# Altium schematic sheet ICWSHLP2_Adaptor_2x2_Connector_FMC.SchDoc(ICWSHLP2_Adaptor_2x2_Connector_FMC)
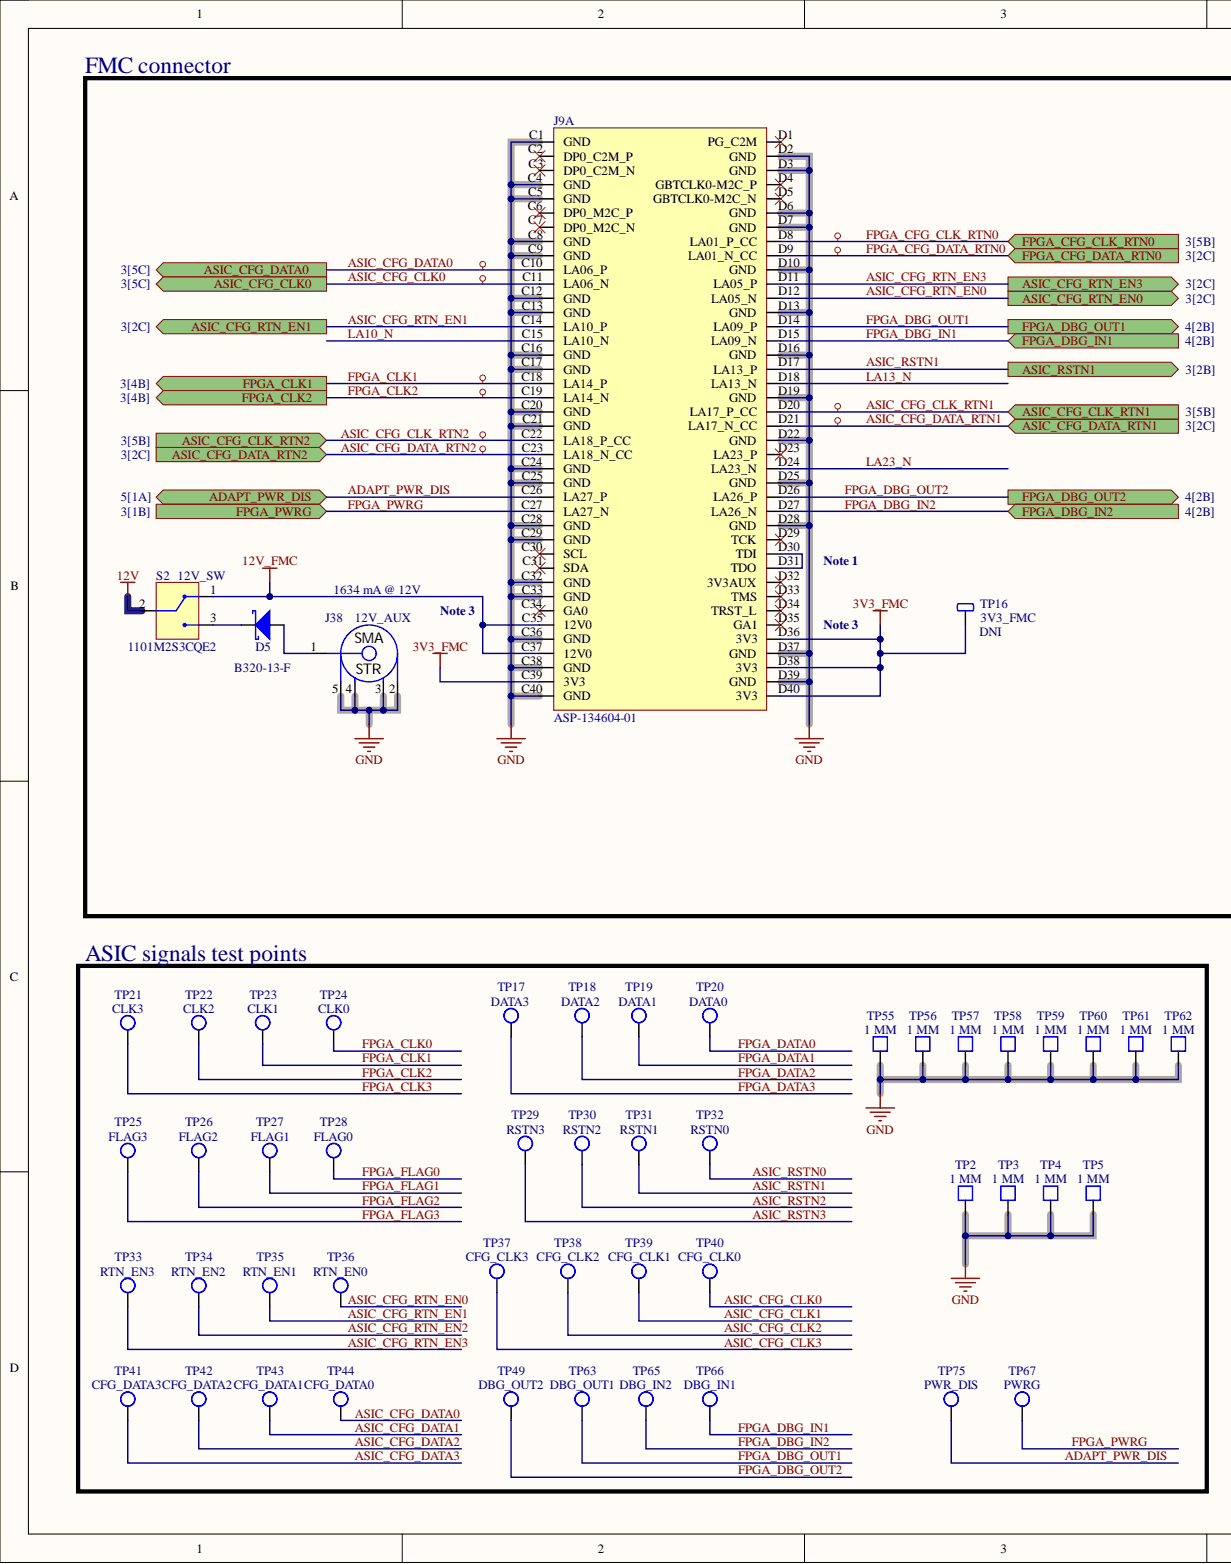
[diagram p0: ICWSHLP2_Adaptor_2x2_Connector_FMC.SchDoc, left]
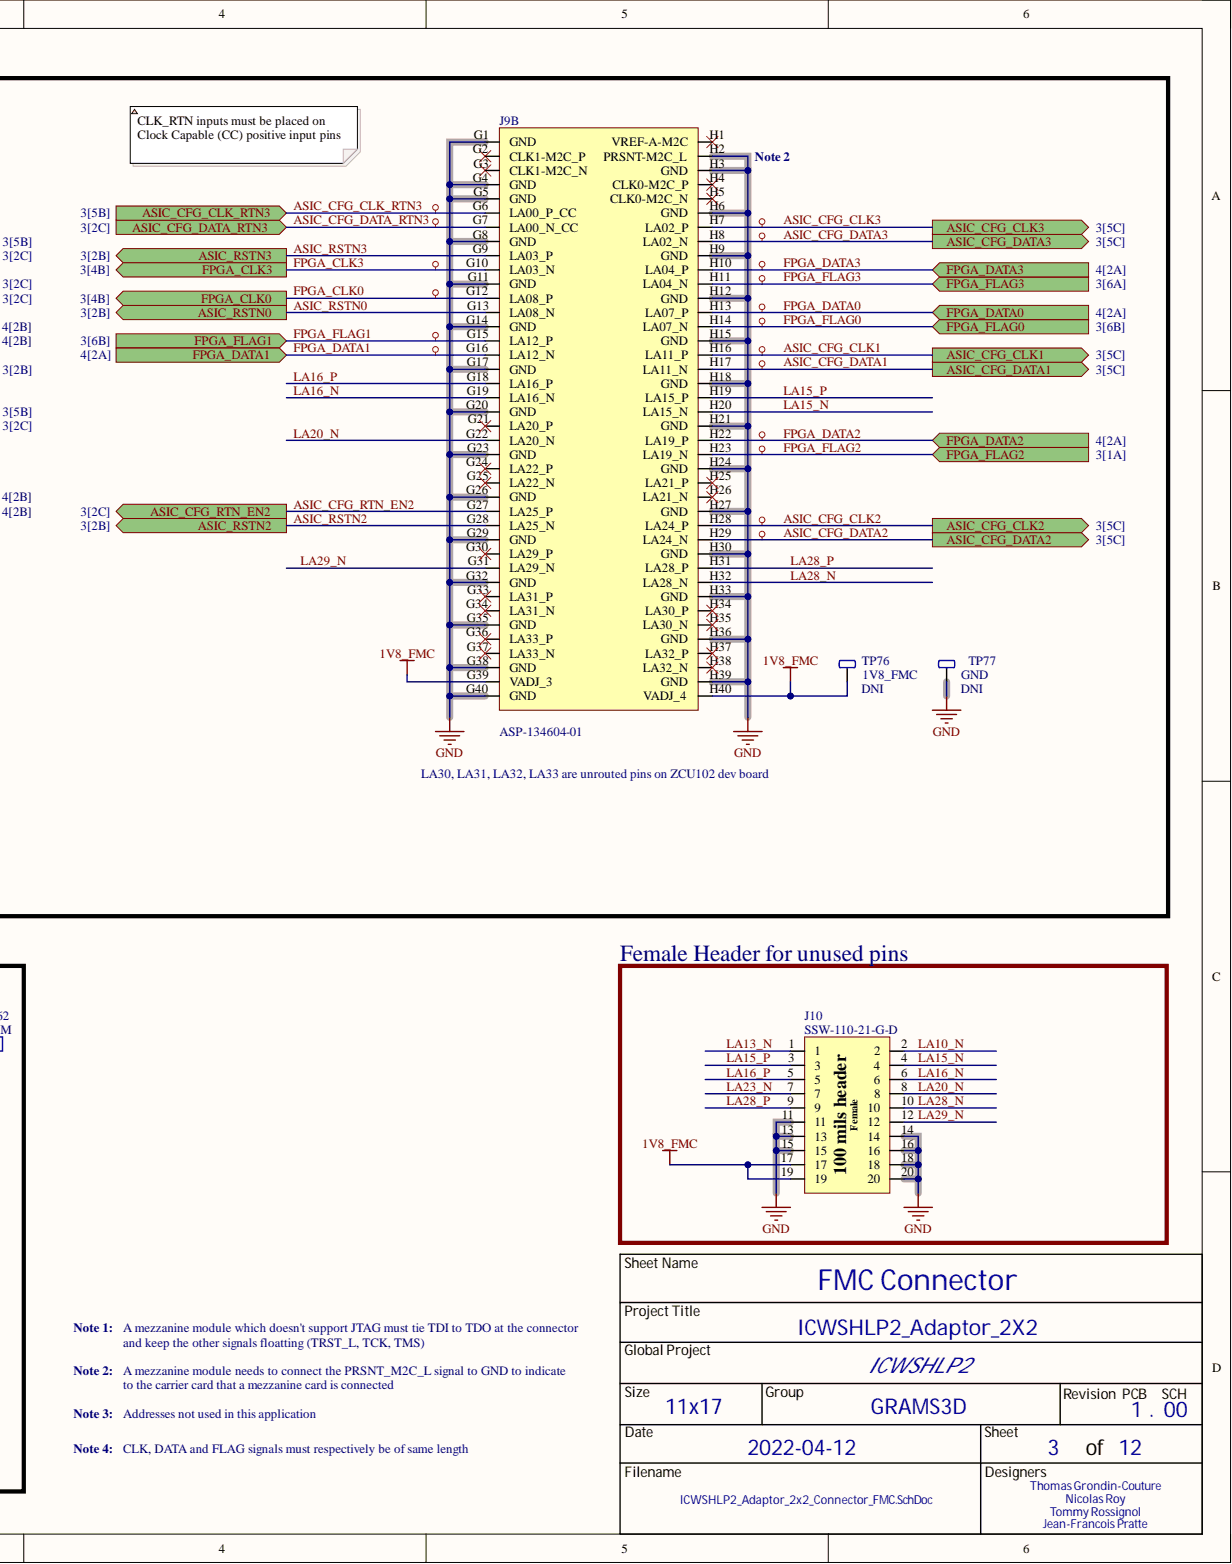
[diagram p1: ICWSHLP2_Adaptor_2x2_Connector_FMC.SchDoc, right]

## Parts
D5  B320-13-F  [DIO_SCHOTTKY_B320-13-F]
J9  ASP-134604-01  Note: MOD_ZedBoard_FMC  [CON_HDR_FMC_LPC_ASP-134604-01]  (parts A,B)
J10  SSW-110-21-G-D  [CON_HDR_SSW-110-21-G-D]
J38  12V_AUX  [CON_SMA_5-1814832-1]
S2  1101M2S3CQE2  [SWI_1101M2S3CQE2]
TP2  1 MM  [TPO_SMD_SQUARE_1MM]  (no BOM)
TP3  1 MM  [TPO_SMD_SQUARE_1MM]  (no BOM)
TP4  1 MM  [TPO_SMD_SQUARE_1MM]  (no BOM)
TP5  1 MM  [TPO_SMD_SQUARE_1MM]  (no BOM)
TP16  3V3_FMC  Assembly Note: DNI  [TPO_SMD_RCU-0C]
TP17  DATA3  [TPO_SMD_ROUND_1MM]  (no BOM)
TP18  DATA2  [TPO_SMD_ROUND_1MM]  (no BOM)
TP19  DATA1  [TPO_SMD_ROUND_1MM]  (no BOM)
TP20  DATA0  [TPO_SMD_ROUND_1MM]  (no BOM)
TP21  CLK3  [TPO_SMD_ROUND_1MM]  (no BOM)
TP22  CLK2  [TPO_SMD_ROUND_1MM]  (no BOM)
TP23  CLK1  [TPO_SMD_ROUND_1MM]  (no BOM)
TP24  CLK0  [TPO_SMD_ROUND_1MM]  (no BOM)
TP25  FLAG3  [TPO_SMD_ROUND_1MM]  (no BOM)
TP26  FLAG2  [TPO_SMD_ROUND_1MM]  (no BOM)
TP27  FLAG1  [TPO_SMD_ROUND_1MM]  (no BOM)
TP28  FLAG0  [TPO_SMD_ROUND_1MM]  (no BOM)
TP29  RSTN3  [TPO_SMD_ROUND_1MM]  (no BOM)
TP30  RSTN2  [TPO_SMD_ROUND_1MM]  (no BOM)
TP31  RSTN1  [TPO_SMD_ROUND_1MM]  (no BOM)
TP32  RSTN0  [TPO_SMD_ROUND_1MM]  (no BOM)
TP33  RTN_EN3  [TPO_SMD_ROUND_1MM]  (no BOM)
TP34  RTN_EN2  [TPO_SMD_ROUND_1MM]  (no BOM)
TP35  RTN_EN1  [TPO_SMD_ROUND_1MM]  (no BOM)
TP36  RTN_EN0  [TPO_SMD_ROUND_1MM]  (no BOM)
TP37  CFG_CLK3  [TPO_SMD_ROUND_1MM]  (no BOM)
TP38  CFG_CLK2  [TPO_SMD_ROUND_1MM]  (no BOM)
TP39  CFG_CLK1  [TPO_SMD_ROUND_1MM]  (no BOM)
TP40  CFG_CLK0  [TPO_SMD_ROUND_1MM]  (no BOM)
TP41  CFG_DATA3  [TPO_SMD_ROUND_1MM]  (no BOM)
TP42  CFG_DATA2  [TPO_SMD_ROUND_1MM]  (no BOM)
TP43  CFG_DATA1  [TPO_SMD_ROUND_1MM]  (no BOM)
TP44  CFG_DATA0  [TPO_SMD_ROUND_1MM]  (no BOM)
TP49  DBG_OUT2  [TPO_SMD_ROUND_1MM]  (no BOM)
TP55  1 MM  [TPO_SMD_SQUARE_1MM]  (no BOM)
TP56  1 MM  [TPO_SMD_SQUARE_1MM]  (no BOM)
TP57  1 MM  [TPO_SMD_SQUARE_1MM]  (no BOM)
TP58  1 MM  [TPO_SMD_SQUARE_1MM]  (no BOM)
TP59  1 MM  [TPO_SMD_SQUARE_1MM]  (no BOM)
TP60  1 MM  [TPO_SMD_SQUARE_1MM]  (no BOM)
TP61  1 MM  [TPO_SMD_SQUARE_1MM]  (no BOM)
TP62  1 MM  [TPO_SMD_SQUARE_1MM]  (no BOM)
TP63  DBG_OUT1  [TPO_SMD_ROUND_1MM]  (no BOM)
TP65  DBG_IN2  [TPO_SMD_ROUND_1MM]  (no BOM)
TP66  DBG_IN1  [TPO_SMD_ROUND_1MM]  (no BOM)
TP67  PWRG  [TPO_SMD_ROUND_1MM]  (no BOM)
TP75  PWR_DIS  [TPO_SMD_ROUND_1MM]  (no BOM)
TP76  1V8_FMC  Assembly Note: DNI  [TPO_SMD_RCU-0C]
TP77  GND  Assembly Note: DNI  [TPO_SMD_RCU-0C]

## Ports
ADAPT_PWR_DIS, ASIC_CFG_CLK0, ASIC_CFG_CLK1, ASIC_CFG_CLK2, ASIC_CFG_CLK3, ASIC_CFG_CLK_RTN1, ASIC_CFG_CLK_RTN2, ASIC_CFG_CLK_RTN3, ASIC_CFG_DATA0, ASIC_CFG_DATA1, ASIC_CFG_DATA2, ASIC_CFG_DATA3, ASIC_CFG_DATA_RTN1, ASIC_CFG_DATA_RTN2, ASIC_CFG_DATA_RTN3, ASIC_CFG_RTN_EN0, ASIC_CFG_RTN_EN1, ASIC_CFG_RTN_EN2, ASIC_CFG_RTN_EN3, ASIC_RSTN0, ASIC_RSTN1, ASIC_RSTN2, ASIC_RSTN3, FPGA_CFG_CLK_RTN0, FPGA_CFG_DATA_RTN0, FPGA_CLK0, FPGA_CLK1, FPGA_CLK2, FPGA_CLK3, FPGA_DATA0, FPGA_DATA1, FPGA_DATA2, FPGA_DATA3, FPGA_DBG_IN1, FPGA_DBG_IN2, FPGA_DBG_OUT1, FPGA_DBG_OUT2, FPGA_FLAG0, FPGA_FLAG1, FPGA_FLAG2, FPGA_FLAG3, FPGA_PWRG

## Nets
1V8_FMC: J9.G39(VADJ_3) J9.H40(VADJ_4) J10.17 J10.19 TP76.1
3V3_FMC: J9.C39(3V3) J9.D36(3V3) J9.D38(3V3) J9.D40(3V3) TP16.1
12V: S2.2
12V_FMC: J9.C35(12V0) J9.C37(12V0) S2.1
GND: J9.C1(GND) J9.C4(GND) J9.C5(GND) J9.C8(GND) J9.C9(GND) J9.C12(GND) J9.C13(GND) J9.C16(GND) J9.C17(GND) J9.C20(GND) J9.C21(GND) J9.C24(GND) J9.C25(GND) J9.C28(GND) J9.C29(GND) J9.C32(GND) J9.C33(GND) J9.C36(GND) J9.C38(GND) J9.C40(GND) J9.D2(GND) J9.D3(GND) J9.D6(GND) J9.D7(GND) J9.D10(GND) J9.D13(GND) J9.D16(GND) J9.D19(GND) J9.D22(GND) J9.D25(GND) J9.D28(GND) J9.D37(GND) J9.D39(GND) J9.G1(GND) J9.G4(GND) J9.G5(GND) J9.G8(GND) J9.G11(GND) J9.G14(GND) J9.G17(GND) J9.G20(GND) J9.G23(GND) J9.G26(GND) J9.G29(GND) J9.G32(GND) J9.G35(GND) J9.G38(GND) J9.G40(GND) J9.H2(PRSNT-M2C_L) J9.H3(GND) J9.H6(GND) J9.H9(GND) J9.H12(GND) J9.H15(GND) J9.H18(GND) J9.H21(GND) J9.H24(GND) J9.H27(GND) J9.H30(GND) J9.H33(GND) J9.H36(GND) J9.H39(GND) J10.11 J10.13 J10.14 J10.15 J10.16 J10.18 J10.20 J38.2 J38.3 J38.4 J38.5 TP2.1 TP3.1 TP4.1 TP5.1 TP55.1 TP56.1 TP57.1 TP58.1 TP59.1 TP60.1 TP61.1 TP62.1 TP77.1
ADAPT_PWR_DIS: J9.C26(LA27_P) TP75.1 ; port ADAPT_PWR_DIS
ASIC_CFG_CLK0: J9.C11(LA06_N) TP40.1 ; port ASIC_CFG_CLK0
ASIC_CFG_CLK1: J9.H16(LA11_P) TP39.1 ; port ASIC_CFG_CLK1
ASIC_CFG_CLK2: J9.H28(LA24_P) TP38.1 ; port ASIC_CFG_CLK2
ASIC_CFG_CLK3: J9.H7(LA02_P) TP37.1 ; port ASIC_CFG_CLK3
ASIC_CFG_CLK_RTN1: J9.D20(LA17_P_CC) ; port ASIC_CFG_CLK_RTN1
ASIC_CFG_CLK_RTN2: J9.C22(LA18_P_CC) ; port ASIC_CFG_CLK_RTN2
ASIC_CFG_CLK_RTN3: J9.G6(LA00_P_CC) ; port ASIC_CFG_CLK_RTN3
ASIC_CFG_DATA0: J9.C10(LA06_P) TP44.1 ; port ASIC_CFG_DATA0
ASIC_CFG_DATA1: J9.H17(LA11_N) TP43.1 ; port ASIC_CFG_DATA1
ASIC_CFG_DATA2: J9.H29(LA24_N) TP42.1 ; port ASIC_CFG_DATA2
ASIC_CFG_DATA3: J9.H8(LA02_N) TP41.1 ; port ASIC_CFG_DATA3
ASIC_CFG_DATA_RTN1: J9.D21(LA17_N_CC) ; port ASIC_CFG_DATA_RTN1
ASIC_CFG_DATA_RTN2: J9.C23(LA18_N_CC) ; port ASIC_CFG_DATA_RTN2
ASIC_CFG_DATA_RTN3: J9.G7(LA00_N_CC) ; port ASIC_CFG_DATA_RTN3
ASIC_CFG_RTN_EN0: J9.D12(LA05_N) TP36.1 ; port ASIC_CFG_RTN_EN0
ASIC_CFG_RTN_EN1: J9.C14(LA10_P) TP35.1 ; port ASIC_CFG_RTN_EN1
ASIC_CFG_RTN_EN2: J9.G27(LA25_P) TP34.1 ; port ASIC_CFG_RTN_EN2
ASIC_CFG_RTN_EN3: J9.D11(LA05_P) TP33.1 ; port ASIC_CFG_RTN_EN3
ASIC_RSTN0: J9.G13(LA08_N) TP32.1 ; port ASIC_RSTN0
ASIC_RSTN1: J9.D17(LA13_P) TP31.1 ; port ASIC_RSTN1
ASIC_RSTN2: J9.G28(LA25_N) TP30.1 ; port ASIC_RSTN2
ASIC_RSTN3: J9.G9(LA03_P) TP29.1 ; port ASIC_RSTN3
FPGA_CFG_CLK_RTN0: J9.D8(LA01_P_CC) ; port FPGA_CFG_CLK_RTN0
FPGA_CFG_DATA_RTN0: J9.D9(LA01_N_CC) ; port FPGA_CFG_DATA_RTN0
FPGA_CLK0: J9.G12(LA08_P) TP24.1 ; port FPGA_CLK0
FPGA_CLK1: J9.C18(LA14_P) TP23.1 ; port FPGA_CLK1
FPGA_CLK2: J9.C19(LA14_N) TP22.1 ; port FPGA_CLK2
FPGA_CLK3: J9.G10(LA03_N) TP21.1 ; port FPGA_CLK3
FPGA_DATA0: J9.H13(LA07_P) TP20.1 ; port FPGA_DATA0
FPGA_DATA1: J9.G16(LA12_N) TP19.1 ; port FPGA_DATA1
FPGA_DATA2: J9.H22(LA19_P) TP18.1 ; port FPGA_DATA2
FPGA_DATA3: J9.H10(LA04_P) TP17.1 ; port FPGA_DATA3
FPGA_DBG_IN1: J9.D15(LA09_N) TP66.1 ; port FPGA_DBG_IN1
FPGA_DBG_IN2: J9.D27(LA26_N) TP65.1 ; port FPGA_DBG_IN2
FPGA_DBG_OUT1: J9.D14(LA09_P) TP63.1 ; port FPGA_DBG_OUT1
FPGA_DBG_OUT2: J9.D26(LA26_P) TP49.1 ; port FPGA_DBG_OUT2
FPGA_FLAG0: J9.H14(LA07_N) TP28.1 ; port FPGA_FLAG0
FPGA_FLAG1: J9.G15(LA12_P) TP27.1 ; port FPGA_FLAG1
FPGA_FLAG2: J9.H23(LA19_N) TP26.1 ; port FPGA_FLAG2
FPGA_FLAG3: J9.H11(LA04_N) TP25.1 ; port FPGA_FLAG3
FPGA_PWRG: J9.C27(LA27_N) TP67.1 ; port FPGA_PWRG
LA10_N: J9.C15(LA10_N) J10.2
LA13_N: J9.D18(LA13_N) J10.1
LA15_N: J9.H20(LA15_N) J10.4
LA15_P: J9.H19(LA15_P) J10.3
LA16_N: J9.G19(LA16_N) J10.6
LA16_P: J9.G18(LA16_P) J10.5
LA20_N: J9.G22(LA20_N) J10.8
LA23_N: J9.D24(LA23_N) J10.7
LA28_N: J9.H32(LA28_N) J10.10
LA28_P: J9.H31(LA28_P) J10.9
LA29_N: J9.G31(LA29_N) J10.12
NetD5_A: D5.A J38.1
NetD5_K: D5.K S2.3
NetJ9_D30: J9.D30(TDI) J9.D31(TDO)

Not connected: J9 C2(DP0_C2M_P) C3(DP0_C2M_N) C6(DP0_M2C_P) C7(DP0_M2C_N) C30(SCL) C31(SDA) C34(GA0) D1(PG_C2M) D4(GBTCLK0-M2C_P) D5(GBTCLK0-M2C_N) D23(LA23_P) D29(TCK) D32(3V3AUX) D33(TMS) D34(TRST_L) D35(GA1) G2(CLK1-M2C_P) G3(CLK1-M2C_N) G21(LA20_P) G24(LA22_P) G25(LA22_N) G30(LA29_P) G33(LA31_P) G34(LA31_N) G36(LA33_P) G37(LA33_N) H1(VREF-A-M2C) H4(CLK0-M2C_P) H5(CLK0-M2C_N) H25(LA21_P) H26(LA21_N) H34(LA30_P) H35(LA30_N) H37(LA32_P) H38(LA32_N)

## Library data of the parts
D5 = DIO_SCHOTTKY_B320-13-F: DIO -  SCHOTTKY 20V 3A  - SMC; Manufacturer #1: Diodes Incorporated; Cryo: UNKNOWN; GRAMS Lib Spec: 2.8; Polarized: YES; Value: 500mV @ 3A; Assembly Type: SMD; Component Kind: Standard; Current Rating: 3A; HelpURL: https://www.diodes.com/assets/Datasheets/ds30923.pdf; Library Name: GRAMS_MASTER_LIB.IntLib; Magnetic Material: UNKNOWN; Package: SMC; Part Number #1: B320-13-F; Supplier 1: Digi-Key; Supplier Part Number 1: B320-FDICT-ND; Temperature: -55°C ~ 125°C; Voltage Rating: 20V; CreatedBy/Date: C.Paulin - 12 nov 2019; VerifiedBy: O.D.Frenette - 2020-06-09
J9 = CON_HDR_FMC_LPC_ASP-134604-01: FMC LPC CONN HDR 160POS SMD VITA 57.1 -SMT; Manufacturer #1: Samtec Inc; Cryo: UNKNOWN; GRAMS Lib Spec: 2.8; Polarized: No; Assembly Type: SMD; Component Kind: Standard; Current Rating: -; HelpURL: http://suddendocs.samtec.com/prints/asp-134604-01.pdf; Library Name: GRAMS_MASTER_LIB.IntLib; Magnetic Material: Unknown; Manufacturer #2: TBD; Package: Vita 160 POS 57.1; Part Number #1: ASP-134604-01; Part Number #2: TBD; Power Max: -; Supplier 1: Digi-Key; Supplier Part Number 1: 612-ASP-134604-01CT-ND‎; Temperature: -; Tolerance: -; Value: -; Voltage rating: -; CreatedBy/Date: L-P Gauthier / 2018-05-28; VerifiedBy: Nicolas Roy/2020-11-20
J10 = CON_HDR_SSW-110-21-G-D: CON - HDR FEMALE SOCKET  2x10 - TH-20; Manufacturer #1: Samtec Inc.; Cryo: UNKNOWN; Current Rating: 4.7A per pin (2 pins powered); GRAMS Lib Spec: 2.7; Polarized: NO; Voltage Rating: 465VAC/655VDC; Assembly Type: TH; Component Kind: Standard; HelpURL: http://suddendocs.samtec.com/catalog_english/ssw_th.pdf; Library Name: GRAMS_MASTER_LIB.IntLib; Magnetic Material: UNKNOWN; Package: TH-20; Part Number #1: SSW-110-21-G-D; Supplier 1: SAMTEC; Supplier Part Number 1: SSW-110-21-G-D; Temperature: -55°C ~ 125°C; CreatedBy/Date: Jonathan Bouchard; VerifiedBy: Nicolas V. (2019-04-30)
J38 = CON_SMA_5-1814832-1: CON - SMA JACK STR 50 OHM PCB - TH; Manufacturer #1: TE Connectivity AMP Connectors; Cryo: UNKNOWN; Current Rating: 1.3 A; GRAMS Lib Spec: 2.8; Polarized: No; Value: 50 ohm; Voltage Rating: 500V; Assembly Type: TH; Component Kind: Standard; HelpURL: http://www.te.com/commerce/DocumentDelivery/DDEController?Action=srchrtrv&DocNm=1814832&DocType=Customer+Drawing&DocLang=English; Library Name: GRAMS_MASTER_LIB.IntLib; Magnetic Material: Unknown; Manufacturer #2: TBD; Package: -; Part Number #1: 5-1814832-1; Part Number #2: TBD; Power Max: -; Supplier 1: Digi-Key; Supplier Part Number 1: A97594-ND; Temperature: -65°C ~ 165°C; Tolerance: -; CreatedBy/Date: L-P Gauthier / 2018-09-12; VerifiedBy: Caroline Paulin - 28 sept 2018
S2 = SWI_1101M2S3CQE2: SWI - SLIDE SPDT 6A 120V - TH; Manufacturer #1: C&K; Cryo: UNKNOWN; GRAMS Lib Spec: 2.8; Polarized: Yes; Voltage Rating: 120VAC/28VDC; Assembly Type: TH; Component Kind: Standard; Current Rating: 6A; HelpURL: https://www.ckswitches.com/products/switches/product-details/Slide/1000/1101M2S3CQE2/; Library Name: GRAMS_MASTER_LIB.IntLib; Magnetic Material: Unknown; Manufacturer #2: -; Package: TH; Part Number #1: 1101M2S3CQE2; Part Number #2: -; Power Max: -; Supplier 1: Digi-Key; Supplier Part Number 1: CKN5001-ND; Temperature: -30°C ~ 65°C; Tolerance: -; Value: SPDT; CreatedBy/Date: Caroline Paulin - 11 mars 2019; VerifiedBy: Nicolas V. (2019-03-25)
TP2-TP5 TP55-TP62 = TPO_SMD_SQUARE_1MM: TPO - TEST POINT SQUARE SMD PAD ONLY  - 1 MM; Cryo: UNKNOWN; GRAMS Lib Spec: 2.8; Magnetic Material: NO; Assembly Type: NONE; Library Name: GRAMS_MASTER_LIB.IntLib; Package: 1 MM SQUARE; CreatedBy/Date: Jonathan Bouchard 2018-07-17; VerifiedBy: Arnaud Samson 23-07-18
TP16 TP76 TP77 = TPO_SMD_RCU-0C: TPO - TEST POINT PROBE PAD - 0603; Manufacturer #1: TE Connectivity AMP Connectors; Part Number #1: RCU-0C; Cryo: UNKNOWN; GRAMS Lib Spec: 2.8; Polarized: No; Value: 1.6 mm x 0.8 mm; Assembly Type: SMD; Component Kind: Standard; HelpURL: http://www.te.com/commerce/DocumentDelivery/DDEController?Action=srchrtrv&DocNm=1773266&DocType=DS&DocLang=English; Library Name: GRAMS_MASTER_LIB.IntLib; Magnetic Material: UNKNOWN; Package: 0603; Supplier 1: Digi-Key; Supplier Part Number 1: A106145CT-ND; CreatedBy/Date: Olivier Bernard / 20/5/2018; VerifiedBy: Caroline Paulin / 6 juillet 2018
TP17-TP44 TP49 TP63 TP65-TP67 TP75 = TPO_SMD_ROUND_1MM: TPO - TEST POINT ROUND SMD PAD ONLY  - 1 MM; Cryo: UNKNOWN; GRAMS Lib Spec: 2.8; Magnetic Material: NO; Assembly Type: NONE; Library Name: GRAMS_MASTER_LIB.IntLib; Package: 1 MM ROUND; CreatedBy/Date: Jonathan Bouchard 2018-07-17; VerifiedBy: Arnaud Samson 23-07-18
